# Altium schematic sheet CIIIB_Sheet2_5ath.SchDoc
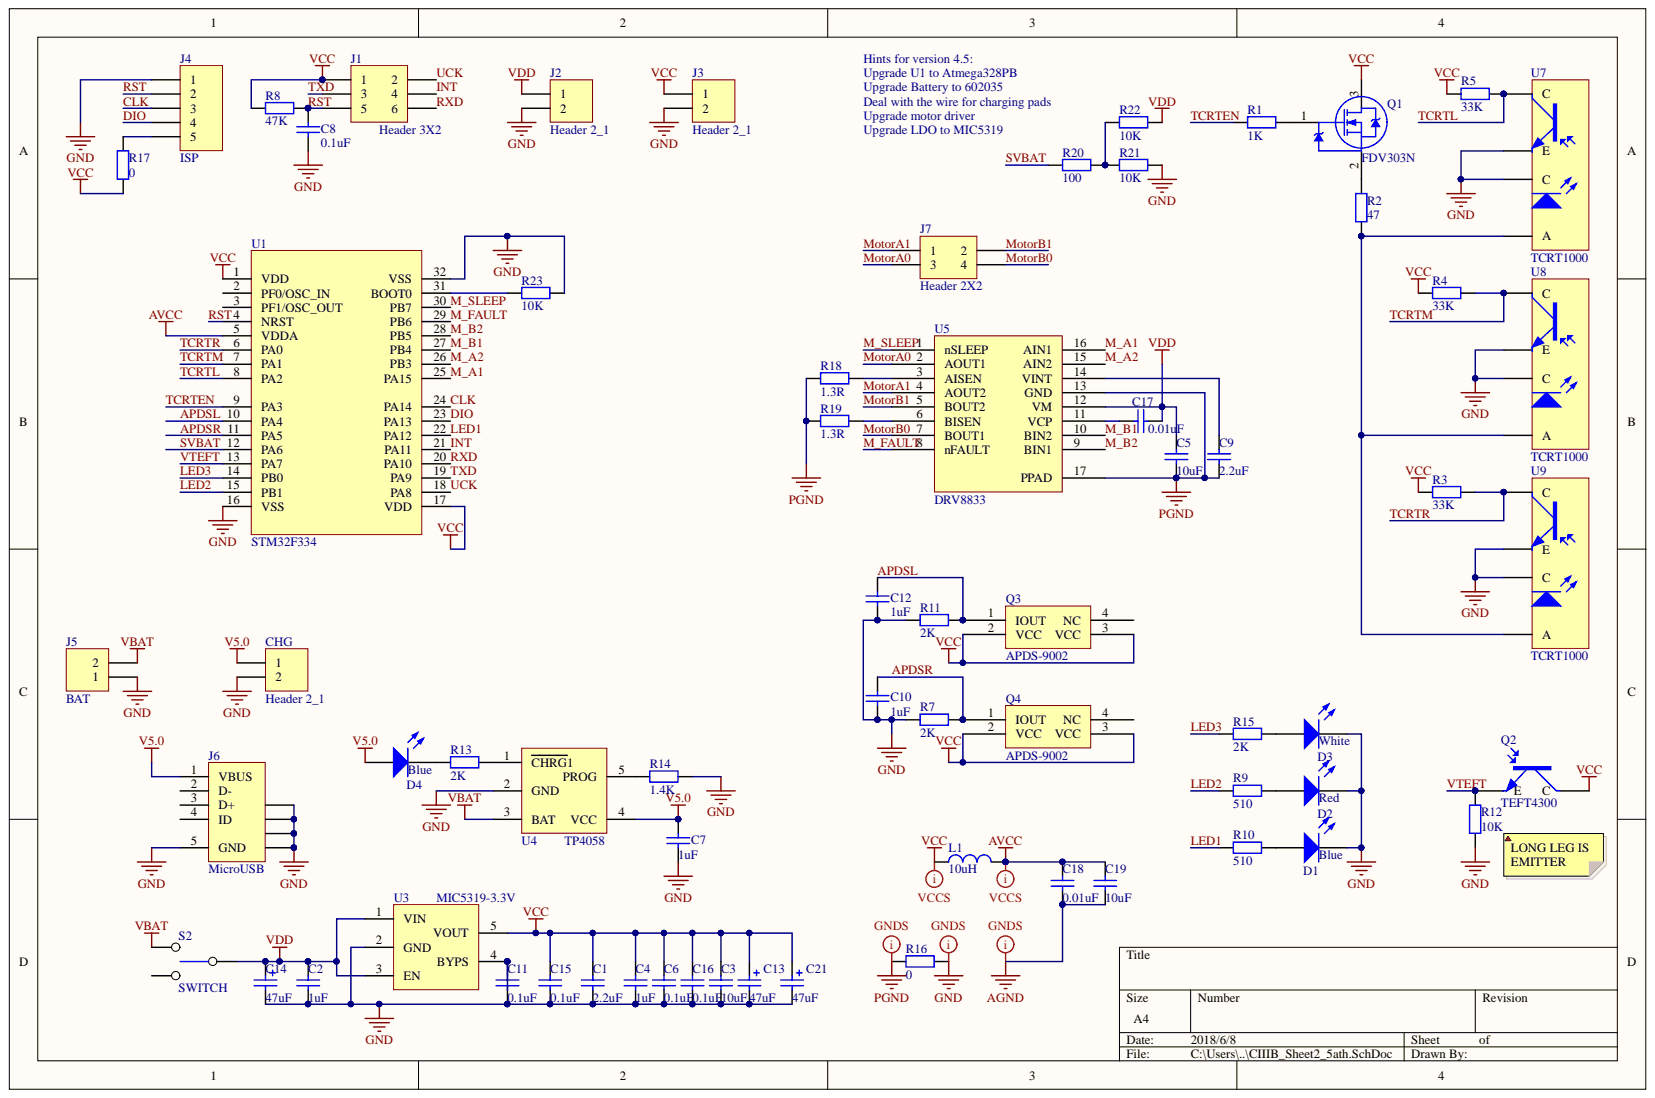
[diagram p0: CIIIB_Sheet2_5ath.SchDoc]

## Parts
C1  2.2uF  [C0603]
C2  1uF  [C0603]
C3  10uF  [C0603]
C4  1uF  [C0603]
C5  10uF  [C0603]
C6  0.1uF  [C0603]
C7  1uF  [C0603]
C8  0.1uF  [C0603]
C9  2.2uF  [C0603]
C10  1uF  [C0603]
C11  0.1uF  [C0603]
C12  1uF  [C0603]
C13  47uF  [3216]
C14  47uF  [3216]
C15  0.1uF  [C0603]
C16  0.1uF  [C0603]
C17  0.01uF  [C0603]
C18  0.01uF  [C0603]
C19  10uF  [C0603]
C21  47uF  [3216]
CHG  Header 2_1  [CHG_SHOE]
D1  Blue  [LED3mm-B]
D2  Red  [LED0603-R]
D3  White  [LED0603-W]
D4  Blue  [LED0603-B]
J1  Header 3X2  [HDR2.00_2X3T]
J2  Header 2_1  [HDR2.00_2X1T]
J3  Header 2_1  [HDR2.00_2X1T]
J4  ISP  [MHDR1X5]
J5  BAT  [PH2.0 2P THR]
J6  MicroUSB  [microUSB BII]
J7  Header 2X2  [HDR2.00_2X2T]
L1  10uH  [L0603]
Q1  FDV303N  [SOT-23]
Q2  TEFT4300  [LED3mm-bent]
Q3  APDS-9002  [APDS-9002_P]
Q4  APDS-9002  [APDS-9002_P]
R1  1K  [R0603]
R2  47  [R1206]
R3  33K  [R0603]
R4  33K  [R0603]
R5  33K  [R0603]
R7  2K  [R0603]
R8  47K  [R0603]
R9  510  [R0603]
R10  510  [R0603]
R11  2K  [R0603]
R12  10K  [R0603]
R13  2K  [R0603]
R14  1.4K  [R0603]
R15  2K  [R0603]
R16  0  [R0805]
R17  0  [R0603]
R18  1.3R  [R0603]
R19  1.3R  [R0603]
R20  100  [R0603]
R21  10K  [R0603]
R22  10K  [R0603]
R23  10K  [R0603]
S2  SWITCH  [SPDT-3pin-side]
U1  STM32F334  [QFP_32A_L]
U3  MIC5319-3.3V  [SOT-23-5]
U4  TP4058  [SOT-23-5]
U5  DRV8833  [TSSOP16_Powerpad]
U7  TCRT1000  [TCRT1000_P]
U8  TCRT1000  [TCRT1000_P]
U9  TCRT1000  [TCRT1000_P]

## Nets
AGND: C18.2 C19.2
AVCC: C18.1 C19.1 L1.2 U1.5(VDDA)
GND: C1.2 C2.2 C3.2 C4.2 C6.2 C7.2 C8.1 C10.1 C11.2 C12.1 C13.2 C14.2 C15.2 C16.2 C21.2 CHG.2 D1.2 D2.2 D3.2 J2.2 J3.2 J4.1 J5.1 J6.5(GND) J6.6 J6.7 J6.8 J6.9 R7.1 R11.1 R12.2 R14.2 R16.1 R21.1 R23.2 U1.16(VSS) U1.32(VSS) U3.2(GND) U4.2(GND) U7.2(C) U7.3(E) U8.2(C) U8.3(E) U9.2(C) U9.3(E)
PGND: C5.2 C9.2 R16.2 R18.2 R19.2 U5.13(GND) U5.17(PPAD)
V5.0: C7.1 CHG.1 D4.1 J6.1(VBUS) U4.4(VCC)
VBAT: J5.2 S2.2 U4.3(BAT)
VCC: C1.1 C3.1 C4.1 C6.1 C13.1 C15.1 C16.1 C21.1 J1.1 J3.1 L1.1 Q1.3 Q2.2 Q3.2(VCC) Q3.3(VCC) Q4.2(VCC) Q4.3(VCC) R3.1 R4.1 R5.1 R8.1 R17.1 U1.1(VDD) U1.17(VDD) U3.5(VOUT)
VDD: C2.1 C5.1 C14.1 C17.2 J2.1 R22.1 S2.1 U3.1(VIN) U3.3(EN) U5.12(VM)
APDSL: C12.2 Q3.1(IOUT) R11.2 U1.10(PA4)
APDSR: C10.2 Q4.1(IOUT) R7.2 U1.11(PA5)
CLK: J4.3 U1.24(PA14)
DIO: J4.4 U1.23(PA13)
INT: J1.4 U1.21(PA11)
LED1: R10.1 U1.22(PA12)
LED2: R9.1 U1.15(PB1)
LED3: R15.1 U1.14(PB0)
M_A1: U1.25(PA15) U5.16(AIN1)
M_A2: U1.26(PB3) U5.15(AIN2)
M_B1: U1.27(PB4) U5.10(BIN2)
M_B2: U1.28(PB5) U5.9(BIN1)
M_FAULT: U1.29(PB6) U5.8(nFAULT)
M_SLEEP: U1.30(PB7) U5.1(nSLEEP)
MotorA0: J7.3 U5.2(AOUT1)
MotorA1: J7.1 U5.4(AOUT2)
MotorB0: J7.4 U5.7(BOUT1)
MotorB1: J7.2 U5.5(BOUT2)
RST: C8.2 J1.5 J4.2 R8.2 U1.4(NRST)
RXD: J1.6 U1.20(PA10)
SVBAT: R20.2 U1.12(PA6)
TCRTEN: R1.1 U1.9(PA3)
TCRTL: R5.2 U1.8(PA2) U7.4(C)
TCRTM: R4.2 U1.7(PA1) U8.4(C)
TCRTR: R3.2 U1.6(PA0) U9.4(C)
TXD: J1.3 U1.19(PA9)
UCK: J1.2 U1.18(PA8)
VTEFT: Q2.1 R12.1 U1.13(PA7)
NetC9_1: C9.1 U5.14(VINT)
NetC11_1: C11.1 U3.4(BYPS)
NetC17_1: C17.1 U5.11(VCP)
NetD1_1: D1.1 R10.2
NetD2_1: D2.1 R9.2
NetD3_1: D3.1 R15.2
NetD4_2: D4.2 R13.1
NetJ4_5: J4.5 R17.2
NetQ1_1: Q1.1 R1.2
NetQ1_2: Q1.2 R2.2
NetR2_1: R2.1 U7.1(A) U8.1(A) U9.1(A)
NetR13_2: R13.2 U4.1(CHRG1)
NetR14_1: R14.1 U4.5(PROG)
NetR18_1: R18.1 U5.3(AISEN)
NetR19_1: R19.1 U5.6(BISEN)
NetR20_1: R20.1 R21.2 R22.2
NetR23_1: R23.1 U1.31(BOOT0)

Not connected: J6 2(D-) 3(D+) 4(ID); Q3 4(NC); Q4 4(NC); S2 3; U1 2(PF0/OSC_IN) 3(PF1/OSC_OUT)

## Library data of the parts
C1-C12 C15-C19 = Cap: Capacitor; Component Kind: Standard; Published: 8-Jun-2000; Revision: July-2002: Re-released for DXP Platform.; Signal Integrity: Model Name; Library Name: Cheng_pcblib.SchLib; Publisher: Altium Limited
  C1 C9: Value: 2.2uF
  C2 C4 C7 C10 C12: Value: 1uF
  C3 C5 C19: Value: 10uF
  C6 C8 C11 C15 C16: Value: 0.1uF
  C17 C18: Value: 0.01uF
C13 C14 C21 = Cap Pol1: Polarized Capacitor 3216; Component Kind: Standard; LatestRevisionDate: 17-Jul-2002; LatestRevisionNote: Re-released for DXP Platform.; PackageReference: RB7.6-15; Published: 8-Jun-2000; Signal Integrity: Cap Pol; Simulation: CAP; Value: 47uF; Library Name: JL_SCH.SchLib; Publisher: Altium Limited
CHG J5 = Header 2_1: Header, 2-Pin; Component Kind: Standard; LatestRevisionDate: 17-Jul-2002; LatestRevisionNote: Re-released for DXP Platform.; Signal Integrity: Connector; Library Name: JL_SCH.SchLib; Publisher: Altium Limited
D1 = LED: LED Blue; Component Kind: Standard; Published: 8-Jun-2000; Revision: July-2002: Re-released for DXP Platform.; Library Name: Cheng_pcblib.SchLib; Publisher: Altium Limited
D2 = LED: LED Red 0603; Component Kind: Standard; Published: 8-Jun-2000; Revision: July-2002: Re-released for DXP Platform.; Library Name: Cheng_pcblib.SchLib; Publisher: Altium Limited
D3 = LED: LED White 0603; Component Kind: Standard; Published: 8-Jun-2000; Revision: July-2002: Re-released for DXP Platform.; Library Name: Cheng_pcblib.SchLib; Publisher: Altium Limited
D4 = LED: LED Blue 0603; Component Kind: Standard; Published: 8-Jun-2000; Revision: July-2002: Re-released for DXP Platform.; Library Name: Cheng_pcblib.SchLib; Publisher: Altium Limited
J1 = Header 3X2: Header, 3-Pin, Dual row; System: Connector; Component Kind: Standard; LatestRevisionDate: 17-Jul-2002; LatestRevisionNote: Re-released for DXP Platform.; Signal Integrity: Connector; Library Name: JL_SCH.SchLib; Publisher: Altium Limited
J2 J3 = Header 2_1: Header, 2-Pin; System: Connector; Component Kind: Standard; LatestRevisionDate: 17-Jul-2002; LatestRevisionNote: Re-released for DXP Platform.; Signal Integrity: Connector; Library Name: JL_SCH.SchLib; Publisher: Altium Limited
J4 = MHDR1X5: Header, 5-Pin; Component Kind: Standard; LatestRevisionDate: 17-Jul-2002; LatestRevisionNote: Re-released for DXP Platform.; Signal Integrity: Connector; Library Name: JL_SCH.SchLib; Publisher: Altium Limited
J6 = MicroUSB-B: MicroUSB connector B type; Component Kind: Standard; Library Name: Cheng_pcblib.SchLib
J7 = Header 2X2: Header, 2-Pin, Dual row; Component Kind: Standard; LatestRevisionDate: 17-Jul-2002; LatestRevisionNote: Re-released for DXP Platform.; Signal Integrity: Connector; Library Name: JL_SCH.SchLib; Publisher: Altium Limited
L1 = Inductor: Code_IEC: 1005; Code_JEITA: 0402; Component Kind: Standard; Description: Inductor; LatestRevisionDate: 17-Jul-2002; LatestRevisionNote: Re-released for DXP Platform.; PackageReference: 0402-A; Published: 8-Jun-2000; Signal Integrity: Inductor; Simulation: INDUCTOR; Value: 10uH; Library Name: Cheng_pcblib.SchLib; Publisher: Altium Limited
R1-R5 R7-R23 = Res2: Resistor; Component Kind: Standard; LatestRevisionDate: 17-Jul-2002; LatestRevisionNote: Re-released for DXP Platform.; PackageReference: AXIAL-0.4; PCB3D: R0805; Published: 8-Jun-2000; Signal Integrity: Res; Simulation: RESISTOR; Library Name: JL_SCH.SchLib; Publisher: Altium Limited
  R1: Value: 1K
  R2: Value: 47
  R3-R5: Value: 33K
  R7 R11 R13 R15: Value: 2K
  R8: Value: 47K
  R9 R10: Value: 510
  R12 R21-R23: Value: 10K
  R14: Value: 1.4K
  R16 R17: Value: 0
  R18 R19: Value: 1.3R
  R20: Value: 100
S2 = SW-SPDT: Component Kind: Standard; Library Name: JL_SCH.SchLib
U1 = STM32F334K8T7: Component Kind: Standard; Library Name: Cheng_pcblib.SchLib
U3 = MIC5319: Component Kind: Standard; Library Name: Cheng_pcblib.SchLib
U4 = TP4054: Component Kind: Standard; Library Name: Cheng_pcblib.SchLib
Q1 = FDV303N: Component Kind: Standard; Description: FDV303N; Library Name: Cheng_pcblib.SchLib
Q2 = TEFT4300: Component Kind: Standard; Description: TEFT4300; Library Name: Cheng_pcblib.SchLib
Q3 Q4 = APDS-9002: Component Kind: Standard; Description: APDS-9002; Library Name: Cheng_pcblib.SchLib
U5 = DRV8833: Component Kind: Standard; Library Name: Cheng_pcblib.SchLib
U7-U9 = TCRT1000: Component Kind: Standard; Description: TCRT1000; Library Name: Cheng_pcblib.SchLib
